# Altium schematic sheet 02_comm_and_navi.SchDoc(02_comm_and_navi)
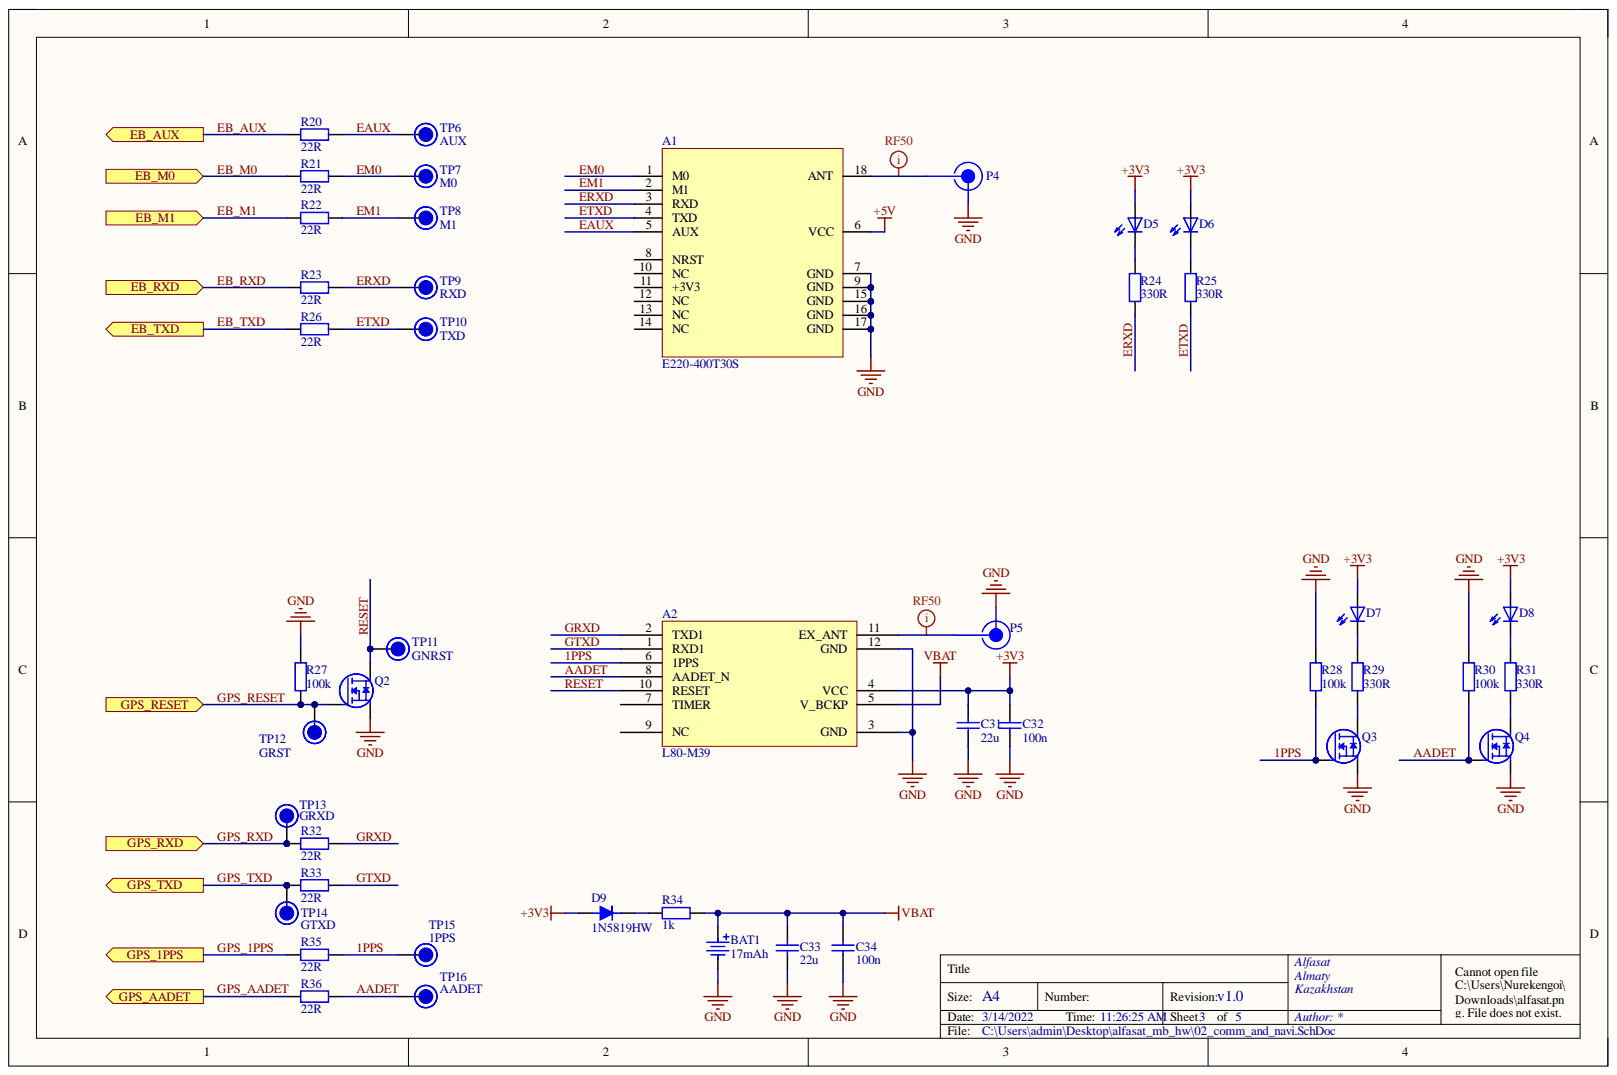
[diagram p0: 02_comm_and_navi.SchDoc]

## Parts
A1  E220-400T30S  [E22-400T30S1B]
A2  L80-M39  [L80-M39]
BAT1  17mAh  [ML1220]
C31  22u  [C0805_IPC_HD]
C32  100n  [C0603_IPC_MD]
C33  22u  [C0805_IPC_HD]
C34  100n  [C0603_IPC_MD]
D5  LTST-C190GKT  [LED_0603]  (value not drawn)
D6  LTST-C190GKT  [LED_0603]  (value not drawn)
D7  LTST-C190GKT  [LED_0603]  (value not drawn)
D8  LTST-C190GKT  [LED_0603]  (value not drawn)
D9  1N5819HW  [1N5819HW_SODFL370X135-2N]
P4  0734150961  [MMCX_EDGE]  (value not drawn)
P5  0734150961  [MMCX_EDGE]  (value not drawn)
Q2  BSS816NW  [SOT323]  (value not drawn)
Q3  BSS816NW  [SOT323]  (value not drawn)
Q4  BSS816NW  [SOT323]  (value not drawn)
R20  22R  [R0603_IPC_MD]
R21  22R  [R0603_IPC_MD]
R22  22R  [R0603_IPC_MD]
R23  22R  [R0603_IPC_MD]
R24  330R  [R0603_IPC_MD]
R25  330R  [R0603_IPC_MD]
R26  22R  [R0603_IPC_MD]
R27  100k  [R0603_IPC_MD]
R28  100k  [R0603_IPC_MD]
R29  330R  [R0603_IPC_MD]
R30  100k  [R0603_IPC_MD]
R31  330R  [R0603_IPC_MD]
R32  22R  [R0603_IPC_MD]
R33  22R  [R0603_IPC_MD]
R34  1k  [R0603_IPC_MD]
R35  22R  [R0603_IPC_MD]
R36  22R  [R0603_IPC_MD]
TP6  AUX  [TestPoint_1M0]  (no BOM)
TP7  M0  [TestPoint_1M0]  (no BOM)
TP8  M1  [TestPoint_1M0]  (no BOM)
TP9  RXD  [TestPoint_1M0]  (no BOM)
TP10  TXD  [TestPoint_1M0]  (no BOM)
TP11  GNRST  [TestPoint_1M0]  (no BOM)
TP12  GRST  [TestPoint_1M0]  (no BOM)
TP13  GRXD  [TestPoint_1M0]  (no BOM)
TP14  GTXD  [TestPoint_1M0]  (no BOM)
TP15  1PPS  [TestPoint_1M0]  (no BOM)
TP16  AADET  [TestPoint_1M0]  (no BOM)

## Ports
EB_AUX, EB_M0, EB_M1, EB_RXD, EB_TXD, GPS_1PPS, GPS_AADET, GPS_RESET, GPS_RXD, GPS_TXD

## Nets
+3V3: A2.4(VCC) C31.1 C32.1 D5.2 D6.2 D7.2 D8.2 D9.1
+5V: A1.6(VCC)
GND: A1.7(GND) A1.9(GND) A1.15(GND) A1.16(GND) A1.17(GND) A2.3(GND) A2.12(GND) BAT1.2 C31.2 C32.2 C33.1 C34.1 P4.1 P5.1 Q2.2 Q3.2 Q4.2 R27.2 R28.2 R30.2
VBAT: A2.5(V_BCKP) BAT1.1 C33.2 C34.2 R34.1
1PPS: A2.6(1PPS) Q3.1 R28.1 R35.2 TP15.0
AADET: A2.8(AADET_N) Q4.1 R30.1 R36.2 TP16.0
EAUX: A1.5(AUX) R20.2 TP6.0
EB_AUX: R20.1 ; port EB_AUX
EB_M0: R21.1 ; port EB_M0
EB_M1: R22.1 ; port EB_M1
EB_RXD: R23.1 ; port EB_RXD
EB_TXD: R26.1 ; port EB_TXD
EM0: A1.1(M0) R21.2 TP7.0
EM1: A1.2(M1) R22.2 TP8.0
ERXD: A1.3(RXD) R23.2 R24.1 TP9.0
ETXD: A1.4(TXD) R25.1 R26.2 TP10.0
GPS_1PPS: R35.1 ; port GPS_1PPS
GPS_AADET: R36.1 ; port GPS_AADET
GPS_RESET: Q2.1 R27.1 TP12.0 ; port GPS_RESET
GPS_RXD: R32.1 TP13.0 ; port GPS_RXD
GPS_TXD: R33.1 TP14.0 ; port GPS_TXD
GRXD: A2.2(TXD1) R32.2
GTXD: A2.1(RXD1) R33.2
RESET: A2.10(RESET) Q2.3 TP11.0
NetA1_18: A1.18(ANT) P4.2
NetA2_11: A2.11(EX_ANT) P5.2
NetD5_1: D5.1 R24.2
NetD6_1: D6.1 R25.2
NetD7_1: D7.1 R29.2
NetD8_1: D8.1 R31.2
NetD9_2: D9.2 R34.2
NetQ3_3: Q3.3 R29.1
NetQ4_3: Q4.3 R31.1

Not connected: A1 8(NRST) 10(NC) 11(+3V3) 12(NC) 13(NC) 14(NC); A2 7(TIMER) 9(NC)

## Library data of the parts
A1 = E220-400T30S: 25x40.5mm LoRa Modules ROHS; Manufacturer: EBYTE; Component Kind: Standard; Manufacturer Part Number: E220-400T30S; Supplier 1: LCSC; Supplier Part Number 1: C970282; Library Name: alfasat_parts_lib.IntLib
A2 = L80-M39: Quectel L80  Compact GPS Module  Integrated with Patch Antenna; Component Kind: Standard; Manufacturer: Quectell; Manufacturer Part Number: L80-M39; Supplier 1: LCSC; Supplier Part Number 1: C133488; Library Name: alfasat_parts_lib.IntLib
BAT1 = ML1220: BATT LITH 3V 17MAH COIN 12.5MM; Manufacturer: China; Component Kind: Standard; Manufacturer Part Number: ML1220; Supplier 1: Aliexpress; Supplier Part Number 1: ML1220; Value: 17mAh; Voltage: 3V; Library Name: alfasat_parts_lib.IntLib
C31 C33 = CL21A226MOCLRNC: CAP CER 22UF 16V X5R 0805; Manufacturer: Samsung Electro-Mechanics; Component Kind: Standard; Dielectric: X5R; Manufacturer Part Number: CL21A226MOCLRNC; Supplier 1: Digi-Key; Supplier Part Number 1: 1276-6780-1-ND; Value: 22u; Voltage: 16V; Library Name: alfasat_parts_lib.IntLib
C32 C34 = CL10B104KO8NNNC: CAP CER 0.1UF 16V X7R 0603; Manufacturer: Samsung Electro-Mechanics; Component Kind: Standard; Dielectric: X7R; Manufacturer Part Number: CL10B104KO8NNNC; Supplier 1: Digi-Key; Supplier Part Number 1: 1276-1005-1-ND; Value: 100n; Voltage: 16V; Library Name: alfasat_parts_lib.IntLib
D5-D8 = LTST-C190GKT: LED GREEN CLEAR CHIP SMD 0603; Manufacturer: Lite-On Inc.; Color: Green; Component Kind: Standard; Manufacturer Part Number: LTST-C190GKT; Supplier 1: Digi-Key; Supplier Part Number 1: 160-1183-1-ND; Library Name: alfasat_parts_lib.IntLib
D9 = 1N5819HW: DIODE SCHOTTKY 40V 1A SOD123; Manufacturer: Diodes Incorporated; Manufacturer Part Number: 1N5819HW-7-F; Component Kind: Standard; Current - Average Rectified (Io): 1A; Supplier 1: Digi-Key; Supplier Part Number 1: 1N5819HW-FDICT-ND; Voltage - DC Reverse (Vr) (Max): 40V; Library Name: alfasat_lib_eps.IntLib
P4 P5 = MMCX_DIGI: CONN MMCX JACK STR 50OHM EDGEMNT; Manufacturer: Molex; Component Kind: Standard; Manufacturer Part Number: 0734150961; Supplier 1: Digi-Key; Supplier Part Number 1: WM5550-ND; Library Name: alfasat_parts_lib.IntLib
Q2-Q4 = BSS816: N-Channel Logic Level Enhancement Mode Field Effect Transistor; Manufacturer: Infineon Technologies; Component Kind: Standard; Manufacturer Part Number: BSS816NW; Supplier 1: Digi-Key; Supplier Part Number 1: BSS816NWH6327XTSA1CT-ND; Library Name: alfasat_parts_lib.IntLib
R20-R23 R26 R32 R33 R35 R36 = CR0603-JW-220ELF: RES SMD 22 OHM 5% 1/10W 0603; Manufacturer: Bourns Inc.; Component Kind: Standard; Manufacturer Part Number: CR0603-JW-220ELF; Supplier 1: Digi-Key; Supplier Part Number 1: CR0603-JW-220ELFCT-ND; Tolarance: 5%; Value: 22R; Library Name: alfasat_parts_lib.IntLib
R24 R25 R29 R31 = CR0603-JW-331ELF: RES SMD 330 OHM 5% 1/10W 0603; Manufacturer: Bourns Inc.; Component Kind: Standard; Manufacturer Part Number: CR0603-JW-331ELF; Supplier 1: Digi-Key; Supplier Part Number 1: CR0603-JW-331ELFCT-ND; Tolarance: 5%; Value: 330R; Library Name: alfasat_parts_lib.IntLib
R27 R28 R30 = RMCF0603FT100K: RES 100K OHM 1% 1/10W 0603; Manufacturer: Bourns Inc.; Component Kind: Standard; Manufacturer Part Number: RMCF0603FT100K; Supplier 1: Digi-Key; Supplier Part Number 1: RMCF0603FT100KCT-ND; Tolarance: 1%; Value: 100k; Library Name: alfasat_parts_lib.IntLib
R34 = RMCF0603FT1K00: RES 1K OHM 1% 1/10W 0603; Manufacturer: Stackpole Electronics Inc; Component Kind: Standard; Manufacturer Part Number: RMCF0603FT1K00; Supplier 1: Digi-Key; Supplier Part Number 1: RMCF0603FT1K00CT-ND; Tolarance: 1%; Value: 1k; Library Name: alfasat_parts_lib.IntLib
TP6-TP16 = TP: Test Point; Library Name: alfasat_parts_lib.IntLib
